# Altium schematic sheet Power.SchDoc
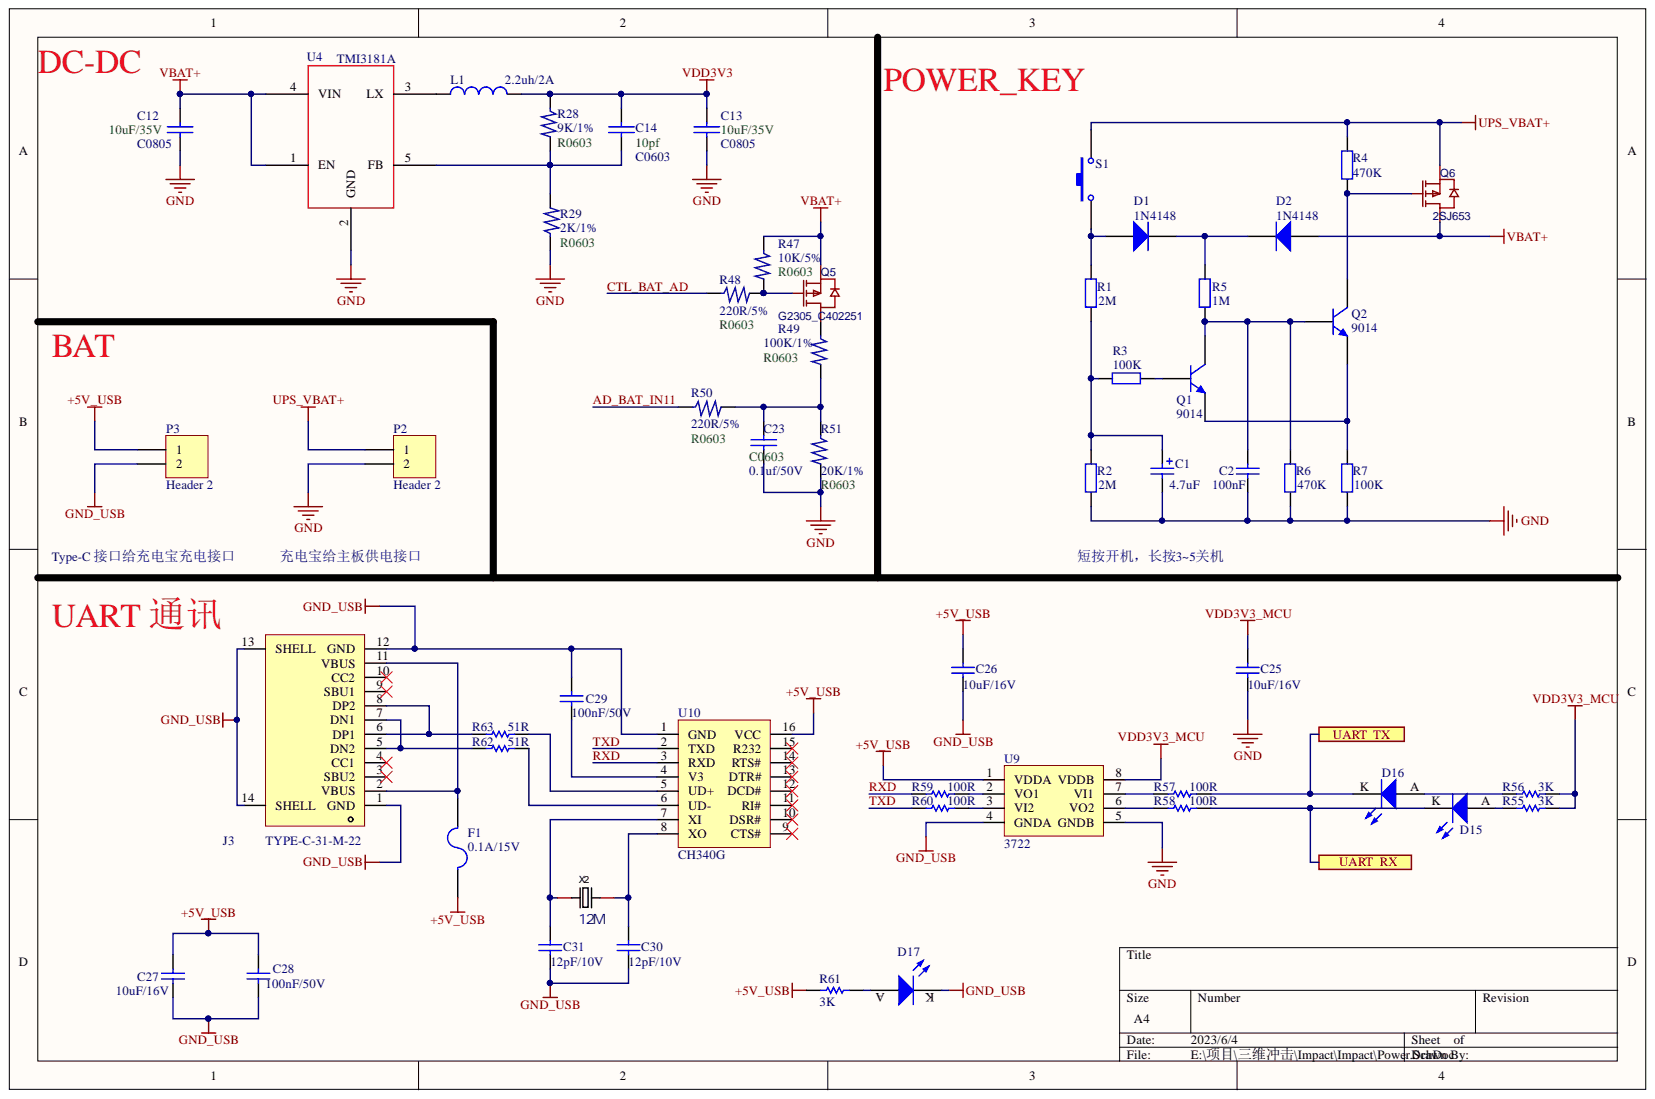
[diagram p0: Power.SchDoc]

## Parts
C1  value 4.7uF  [POLAR0.8]  (hidden comment: Cap Pol2)
C2  value 100nF  [RAD-0.3]  (hidden comment: Cap)
C12  10uF/35V  value C0805  [0805_C]
C13  10uF/35V  value C0805  [0805_C]
C14  10pf  value C0603  [0603_C]
C23  C0603  value 0.1uf/50V  [0603_C]
C25  value 10uF/16V  [0603C]  (hidden comment: Cap)
C26  value 10uF/16V  [0603C]  (hidden comment: Cap)
C27  value 10uF/16V  [0603C]  (hidden comment: Cap)
C28  value 100nF/50V  [0603C]  (hidden comment: Cap)
C29  value 100nF/50V  [0603C]  (hidden comment: Cap)
C30  value 12pF/10V  [0603C]  (hidden comment: Cap)
C31  value 12pF/10V  [0603C]  (hidden comment: Cap)
D1  1N4148  [DO-35]
D2  1N4148  [DO-35]
D15  LED0  [0603LED]  (value not drawn)
D16  LED0  [0603LED]  (value not drawn)
D17  LED0  [0603LED]  (value not drawn)
F1  0.1A/15V  [1812 F保险管]
J3  TYPE-C-31-M-22  [TYPE-C 16PIN 2MD(073)]
L1  2.2uh/2A  [IND-SMD_L3.0-W3.0]
P2  Header 2  [CONN-TH_2P-P2.50_XH-2AW]
P3  Header 2  [CONN-TH_2P-P2.50_XH-2AW]
Q1  9014  [SOT-23B_L]
Q2  9014  [SOT-23B_L]
Q5  G2305_C402251  [SOT-23-3L]
Q6  2SJ653  [SOT-23-3L]
R1  value 2M  [AXIAL-0.4]  (hidden comment: Res2)
R2  value 2M  [AXIAL-0.4]  (hidden comment: Res2)
R3  value 100K  [AXIAL-0.4]  (hidden comment: Res2)
R4  value 470K  [AXIAL-0.4]  (hidden comment: Res2)
R5  value 1M  [AXIAL-0.4]  (hidden comment: Res2)
R6  value 470K  [AXIAL-0.4]  (hidden comment: Res2)
R7  value 100K  [AXIAL-0.4]  (hidden comment: Res2)
R28  9K/1%  [R0603]
R29  2K/1%  [R0603]
R47  10K/5%  [R0603]
R48  220R/5%  [R0603]
R49  100K/1%  [R0603]
R50  220R/5%  [R0603]
R51  20K/1%  [R0603]
R55  3K  [0603R]
R56  3K  [0603R]
R57  100R  [0603R]
R58  100R  [0603R]
R59  100R  [0603R]
R60  100R  [0603R]
R61  3K  [0603R]
R62  51R  [0603R]
R63  51R  [0603R]
S1  SW-PB  [SPST-2]  (value not drawn)
U4  TMI3181A  [SOT-23-5]
U9  3722  [CA-IS3722HS]
U10  CH340G  [CH340G]
X2  12M  [XJHCELNANF-12MHZ]

## Ports
UART  RX, UART  TX

## Nets
+5V_USB: C26.2 C27.2 C28.2 F1.2 P3.1 R61.1 U9.1(VDDA) U10.16(VCC)
GND: C1.2 C2.1 C12.2 C13.2 C23.2 C25.1 P2.2 R2.1 R6.1 R7.1 R29.2 R51.1 U4.2(GND) U9.5(GNDB)
GND_USB: C26.1 C27.1 C28.1 C29.2 C30.1 C31.1 D17.2 J3.1(GND) J3.12(GND) J3.13(SHELL) J3.14(SHELL) P3.2 U9.4(GNDA) U10.1(GND)
UPS_VBAT+: P2.1 Q6.2 R4.1 S1.2
VBAT+: C12.1 D2.1 Q5.2 Q6.3 R47.2 U4.1(EN) U4.4(VIN)
VDD3V3: C13.1 C14.2 L1.1 R28.2
VDD3V3_MCU: C25.2 R55.2 R56.2 U9.8(VDDB)
AD_BAT_IN11: R50.2
CTL_BAT_AD: R48.2
RXD: R59.1 U10.3(RXD)
TXD: R60.1 U10.2(TXD)
UART  RX: D15.2 R58.2 ; port UART  RX
UART  TX: D16.2 R57.2 ; port UART  TX
NetC1_1: C1.1 R1.1 R2.2 R3.1
NetC2_2: C2.2 Q1.1 Q2.2 R5.1 R6.2
NetC14_1: C14.1 R28.1 R29.1 U4.5(FB)
NetC23_1: C23.1 R49.1 R50.1 R51.2
NetC29_1: C29.1 U10.4(V3)
NetC30_2: C30.2 U10.8(XO) X2.2
NetC31_2: C31.2 U10.7(XI) X2.1
NetD1_1: D1.1 R1.2 S1.1
NetD1_2: D1.2 D2.2 R5.2
NetD15_1: D15.1 R55.1
NetD16_1: D16.1 R56.1
NetD17_1: D17.1 R61.2
NetF1_1: F1.1 J3.2(VBUS) J3.11(VBUS)
NetJ3_5: J3.5(DN2) J3.7(DN1) R62.1
NetJ3_6: J3.6(DP1) J3.8(DP2) R63.1
NetL1_2: L1.2 U4.3(LX)
NetQ1_2: Q1.2 R3.2
NetQ1_3: Q1.3 Q2.3 R7.2
NetQ2_1: Q2.1 Q6.1 R4.2
NetQ5_1: Q5.1 R47.1 R48.1
NetQ5_3: Q5.3 R49.2
NetR57_1: R57.1 U9.7(VI1)
NetR58_1: R58.1 U9.6(VO2)
NetR59_2: R59.2 U9.2(VO1)
NetR60_2: R60.2 U9.3(VI2)
NetR62_2: R62.2 U10.6(UD-)
NetR63_2: R63.2 U10.5(UD+)

Not connected: J3 3(SBU2) 4(CC1) 9(SBU1) 10(CC2); U10 9(CTS#) 10(DSR#) 11(RI#) 12(DCD#) 13(DTR#) 14(RTS#) 15(R232)

## Library data of the parts
C1 = Cap Pol2: Polarized Capacitor (Axial); Component Kind: Standard; LatestRevisionDate: 17-Jul-2002; LatestRevisionNote: Re-released for DXP Platform.; Library Name: Miscellaneous Devices.IntLib; PackageDescription: Polarized Capacitor; 2 Leads; PackageReference: POLAR0.8; Published: 8-Jun-2000; Signal Integrity: Cap Pol; Simulation: CAP; Publisher: Altium Limited
C2 C25-C31 = Cap: Capacitor; Component Kind: Standard; LatestRevisionDate: 17-Jul-2002; LatestRevisionNote: Re-released for DXP Platform.; Library Name: Miscellaneous Devices.IntLib; PackageDescription: Radial Cap, Thru-Hole; 2 Leads; 0.3 in Pin Spacing; PackageReference: RAD-0.3; Published: 8-Jun-2000; Signal Integrity: Cap; Simulation: CAP; Publisher: Altium Limited
C12-C14 = CAP: Component Kind: Standard
  C12 C13: Description: 10uf/35V
  C14: Description: 10pf
C23 = CAP: 0.1uf/50V; Component Kind: Standard
D1 D2 = Diode 1N4148: High Conductance Fast Diode; Code_JEDEC: DO-204-AH; Component Kind: Standard; ComponentLink1Description: Manufacturer Link; ComponentLink1URL: http://www.fairchildsemi.com/; ComponentLink2Description: Datasheet; ComponentLink2URL: http://www.fairchildsemi.com/ds/1N%2F1N4148.pdf; DatasheetVersion: 1997; LatestRevisionDate: 17-Jul-2002; LatestRevisionNote: Re-released for DXP Platform.; Library Name: Miscellaneous Devices.IntLib; PackageDescription: Diode, Axial; Body 3.8 x 1.9 mm (LxDia. max), Lead Dia. 0.53 mm (max); PackageReference: DO-35; Published: 8-Jun-2000; Signal Integrity: 1N4148; Simulation: 1N4148; Publisher: Altium Limited
D15-D17 = LED0: Typical INFRARED GaAs LED; Component Kind: Standard; LatestRevisionDate: 08-Jul-2005; LatestRevisionNote: Mech Layer 1 removed.; Library Name: Miscellaneous Devices.IntLib; PackageDescription: LED; 2 Leads; PackageReference: LED-0; Published: 8-Jun-2000; Simulation: LED0; Publisher: Altium Limited
F1 = Fuse 2: Fuse; Component Kind: Standard; LatestRevisionDate: 17-Jul-2002; LatestRevisionNote: Re-released for DXP Platform.; Library Name: Miscellaneous Devices.IntLib; PackageDescription: Thru-Hole; 2 Leads; PackageReference: PIN-W2/E2.8; Published: 8-Jun-2000; Simulation: FUSE; Publisher: Altium Limited
J3 = SHELL: Component Kind: Standard; Library Name: My integrated.SchLib
L1 = L: : C43389; Component Kind: Standard
P2 P3 = Header 2: Header, 2-Pin; Component Kind: Standard; LatestRevisionDate: 17-Jul-2002; LatestRevisionNote: Re-released for DXP Platform.; Library Name: Miscellaneous Connectors.IntLib; Signal Integrity: Connector; Publisher: Altium Limited
Q1 Q2 = QNPN: NPN Bipolar Transistor; Component Kind: Standard; LatestRevisionDate: 29-May-2009; LatestRevisionNote: IPC-7351 Footprint Added.; Library Name: Miscellaneous Devices.IntLib; PackageDescription: 3-Pin SOT-23 Package 0.95 mm Pitch, 2.4 mm Lead Span; PackageReference: SOT-23B; PackageVersion: Aug-1999; Published: 8-Jun-2000; Signal Integrity: NPN; Simulation: QNPN; Publisher: Altium Limited
Q5 Q6 = G2305_C402251: BOM_Manufacturer: LGE; BOM_Manufacturer Part: G2305; Contributor: LCSC; BOM_JLCPCB Part Class: Extended Part; BOM_Supplier: LCSC; BOM_Supplier Part: C402251; Component Kind: Standard; spicePre: Q; spiceSymbolName: G2305_C402251
R1-R7 = Res2: Resistor; Component Kind: Standard; LatestRevisionDate: 17-Jul-2002; LatestRevisionNote: Re-released for DXP Platform.; Library Name: Miscellaneous Devices.IntLib; PackageDescription: Axial Device, Thru-Hole; 2 Leads; 0.4 in Pin Spacing; PackageReference: AXIAL-0.4; Published: 8-Jun-2000; Signal Integrity: Res; Simulation: RESISTOR; Publisher: Altium Limited
R28 R29 R47-R51 = 电阻: Component Kind: Standard
R55-R63 = Res1: Resistor; Value: 1K; Component Kind: Standard; LatestRevisionDate: 17-Jul-2002; LatestRevisionNote: Re-released for DXP Platform.; Library Name: Miscellaneous Devices.IntLib; PackageDescription: Axial Device, Thru-Hole; 2 Leads; 0.3 in Pin Spacing; PackageReference: AXIAL-0.3; Published: 8-Jun-2000; Signal Integrity: Res; Simulation: RESISTOR; Publisher: Altium Limited
S1 = SW-PB: Switch; Component Kind: Standard; LatestRevisionDate: 17-Jul-2002; LatestRevisionNote: Re-released for DXP Platform.; Library Name: Miscellaneous Devices.IntLib; PackageDescription: Switch; 2 Leads; PackageReference: SPST-2; Published: 24-Mar-1999; Publisher: Altium Limited
X2 = XTAL: Designer: U?; Device: Q13FC1350000400; Component Kind: Standard; Library Name: My integrated.SchLib; Symbol: Q13FC1350000400
U4 = TMI3181A: Component Kind: Standard; Library Name: Schlib1.SchLib
U9 = 3722: Component Kind: Standard; Library Name: My integrated.SchLib
U10 = CH340G: Component Kind: Standard; Library Name: My integrated.SchLib
